# Altium schematic sheet Main.SchDoc(Main)
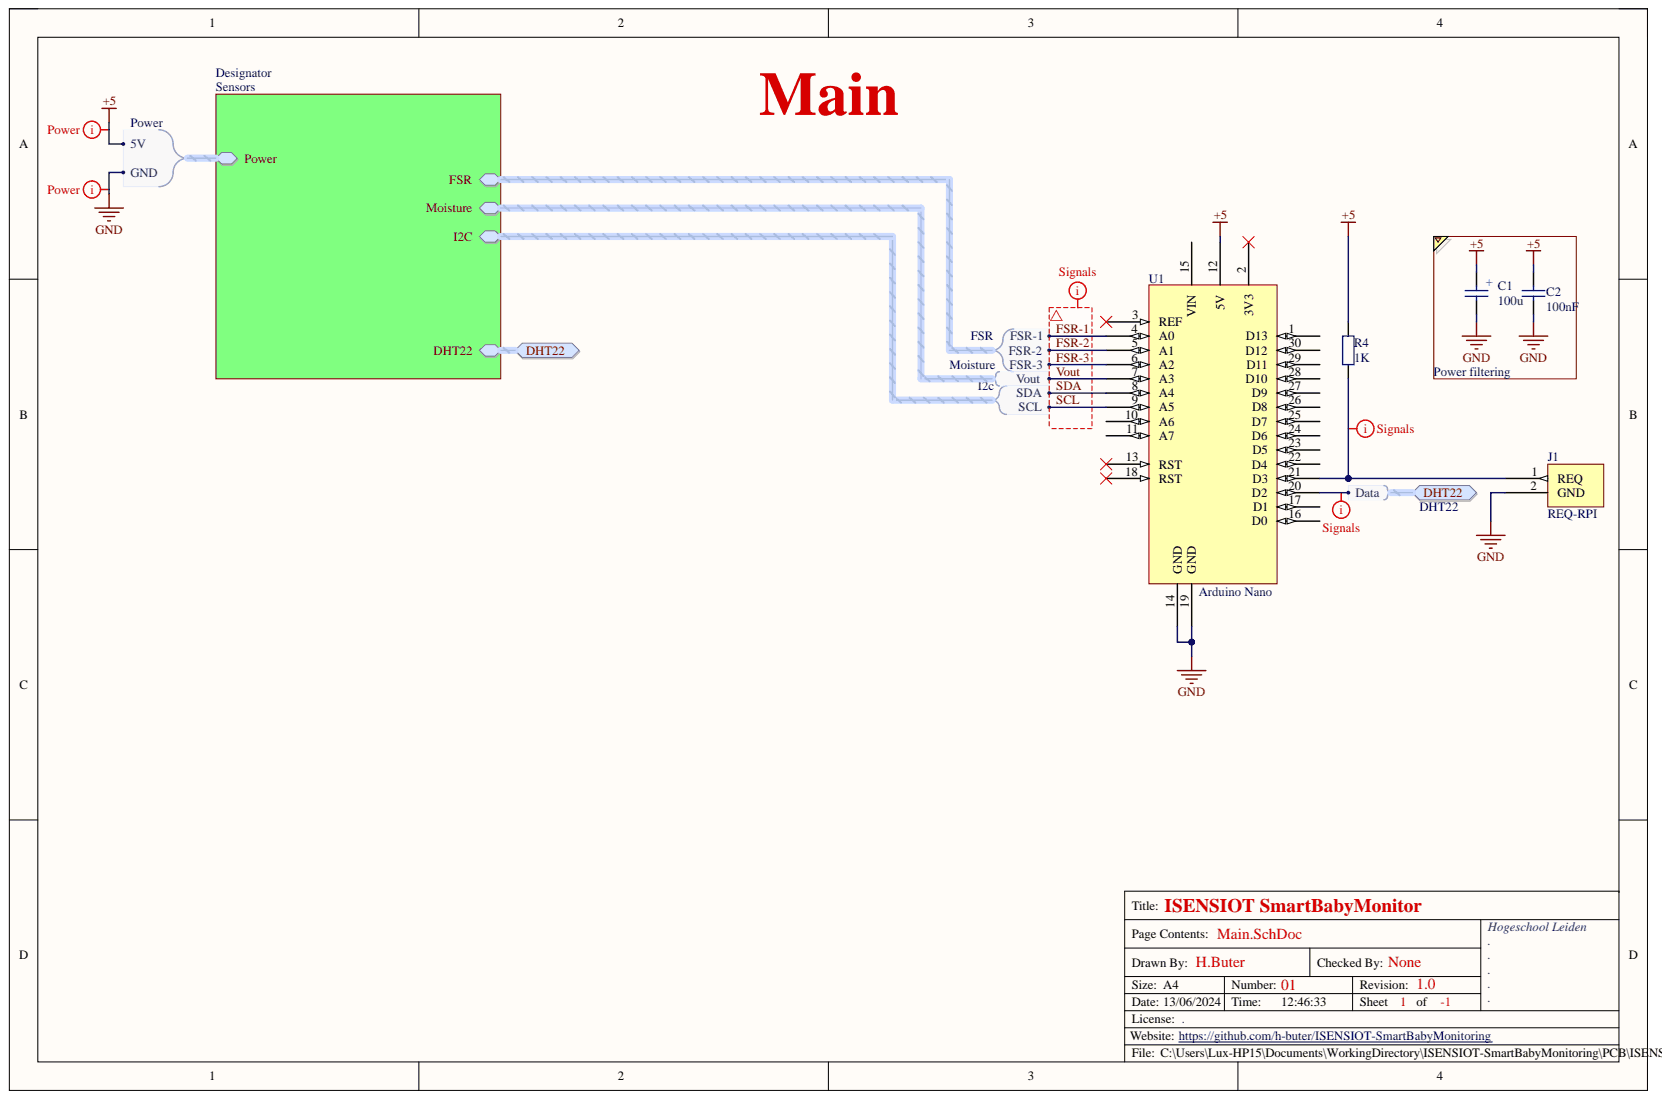
[diagram p0: Main.SchDoc]

## Parts
C1  100u  [Cap 100uF 16V 5x12mm]
C2  100nF  [C1206]
J1  REQ-RPI  [JST-XH 2pin]
R4  1K  [R1206]
U1  Arduino Nano  [Arduino Nano]

## Ports
DHT22, DHT22.Data

## Nets
+5: C1.1 C2.2 R4.2 U1.12(5V)
GND: C1.2 C2.1 J1.2 U1.14(GND) U1.19(GND)
DHT22: U1.20(D2) ; port DHT22
FSR-1: U1.4(A0)
FSR-2: U1.5(A1)
FSR-3: U1.6(A2)
SCL: U1.9(A5)
SDA: U1.8(A4)
Vout: U1.7(A3)
NetJ1_1: J1.1 R4.1 U1.21(D3)
NetU1_1: U1.1(D13)
NetU1_2: U1.2(3V3)
NetU1_3: U1.3(REF)
NetU1_10: U1.10(A6)
NetU1_11: U1.11(A7)
NetU1_13: U1.13(RST)
NetU1_15: U1.15(VIN)
NetU1_16: U1.16(D0)
NetU1_17: U1.17(D1)
NetU1_18: U1.18(RST)
NetU1_22: U1.22(D4)
NetU1_23: U1.23(D5)
NetU1_24: U1.24(D6)
NetU1_25: U1.25(D7)
NetU1_26: U1.26(D8)
NetU1_27: U1.27(D9)
NetU1_28: U1.28(D10)
NetU1_29: U1.29(D11)
NetU1_30: U1.30(D12)

## Library data of the parts
C1 = Cap 100uF 16V 5x12mm: Component Kind: Standard; Description: Cap 100uF 16V 5x12mm; value: 100u; Library Name: ISENSIOT.SchLib
C2 = Capacitor_1206: SMD Capacitor 1206; Component Kind: Standard; LatestRevisionDate: 03-11-2016; LatestRevisionNote: Creation; PackageDescription: Chip Capacitor, Body 3.2x1.6mm, Height 1.7mm, IPC Medium Density; PackageReference: C1206; Published: 03-11-2016; Signal Integrity: Cap; Simulation: CAP; Value: 100nF; Library Name: WindesheimLibrary_v5.IntLib; Publisher: Windesheim
J1 = REQ-RPI: Request connection to Raspberry Pi; Component Kind: Standard; Library Name: ISENSIOT.SchLib
R4 = Resistor_1206: SMD Resistor 1206; Component Kind: Standard; LatestRevisionDate: 03-11-2016; LatestRevisionNote: Creation; PackageDescription: Chip Resistor, Body 3.2x1.6mm, IPC Medium Density; PackageReference: R1206; Published: 03-11-2016; Signal Integrity: Res; Simulation: RESISTOR; Value: 1K; Library Name: WindesheimLibrary_v5.IntLib; Publisher: Windesheim
U1 = Arduino Nano: Component Kind: Standard; Description: Arduino Nano; Library Name: ISENSIOT.SchLib
